# Altium schematic sheet POWER.SchDoc
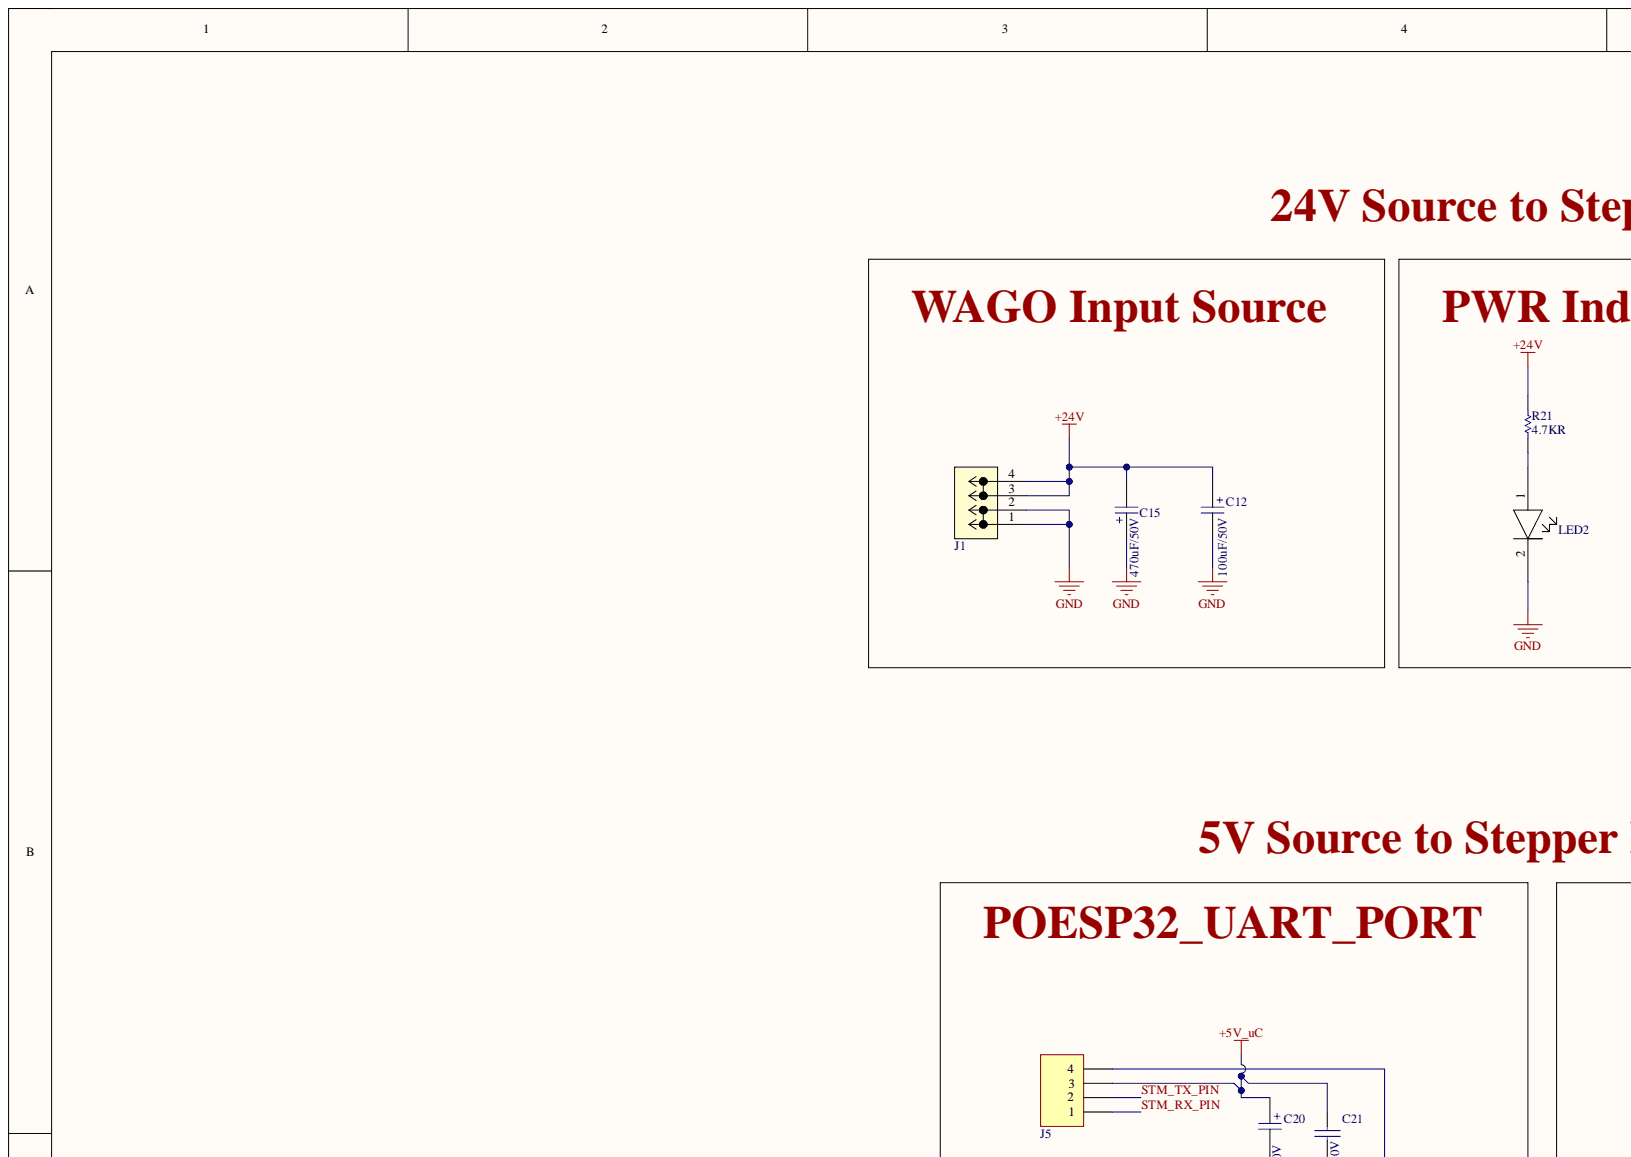
[diagram p0: POWER.SchDoc, top left]
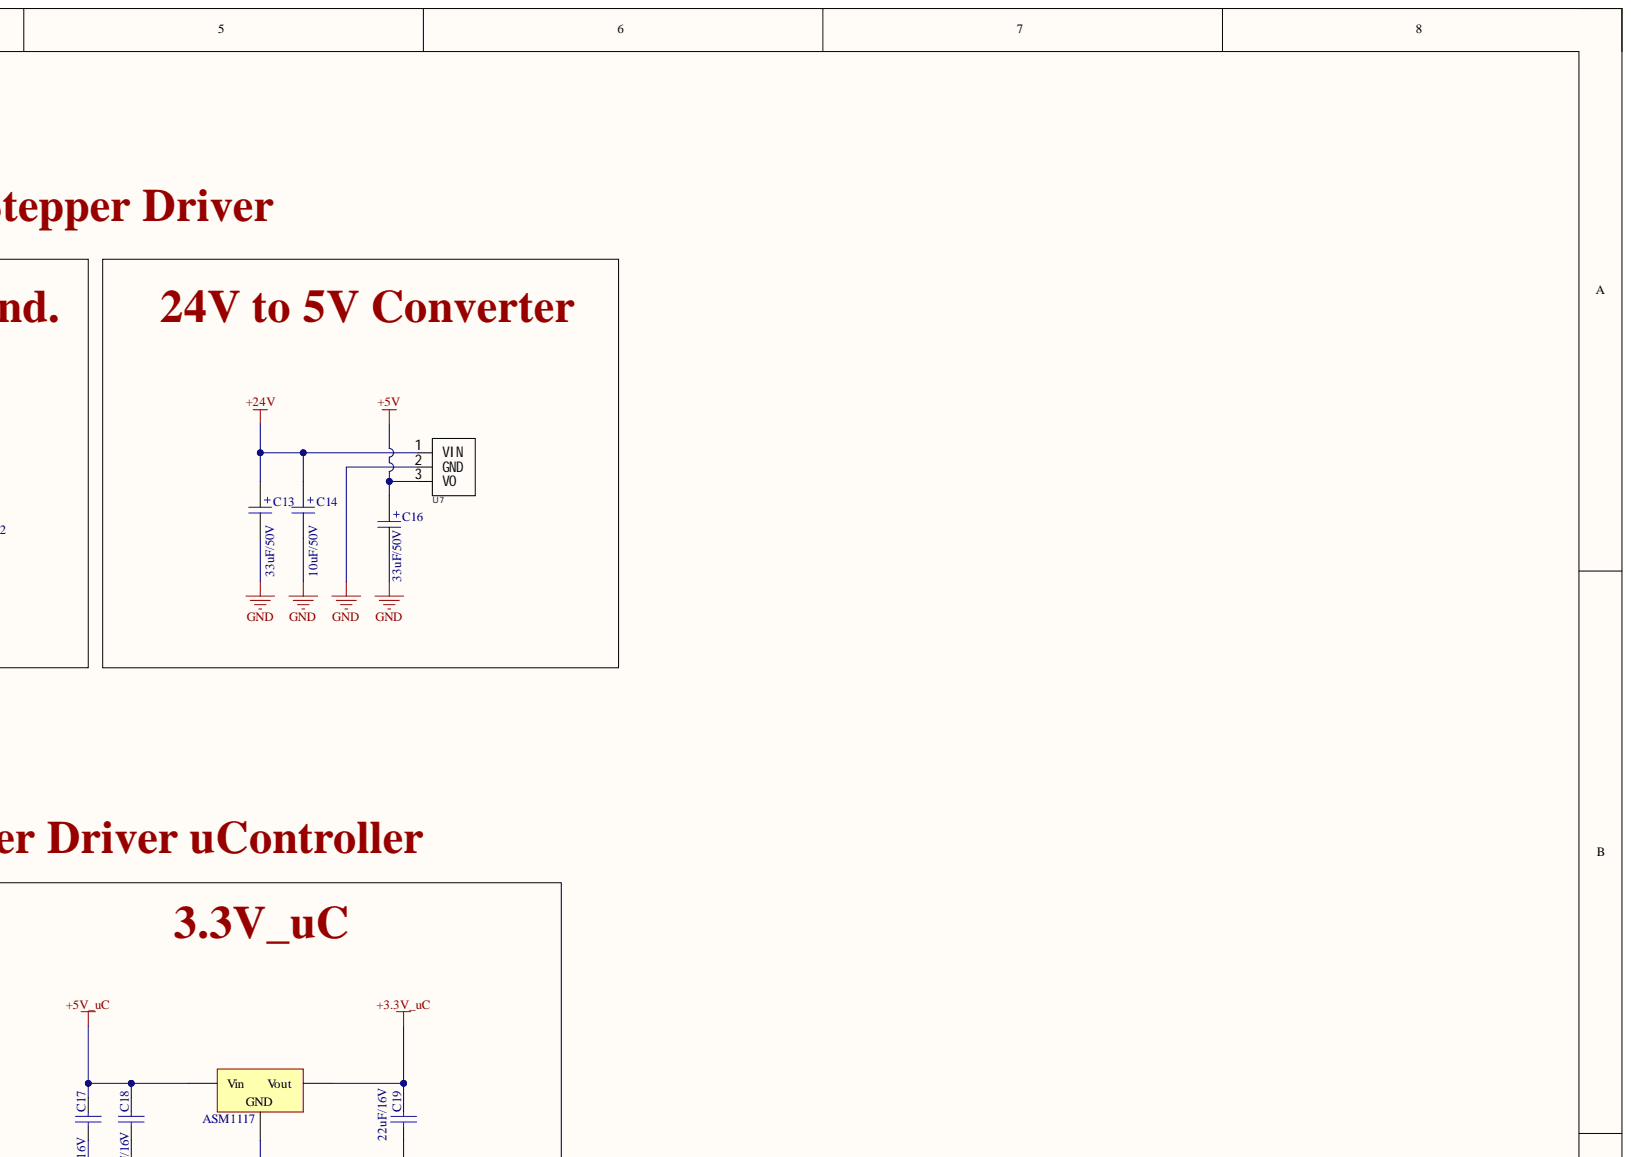
[diagram p1: POWER.SchDoc, top right]
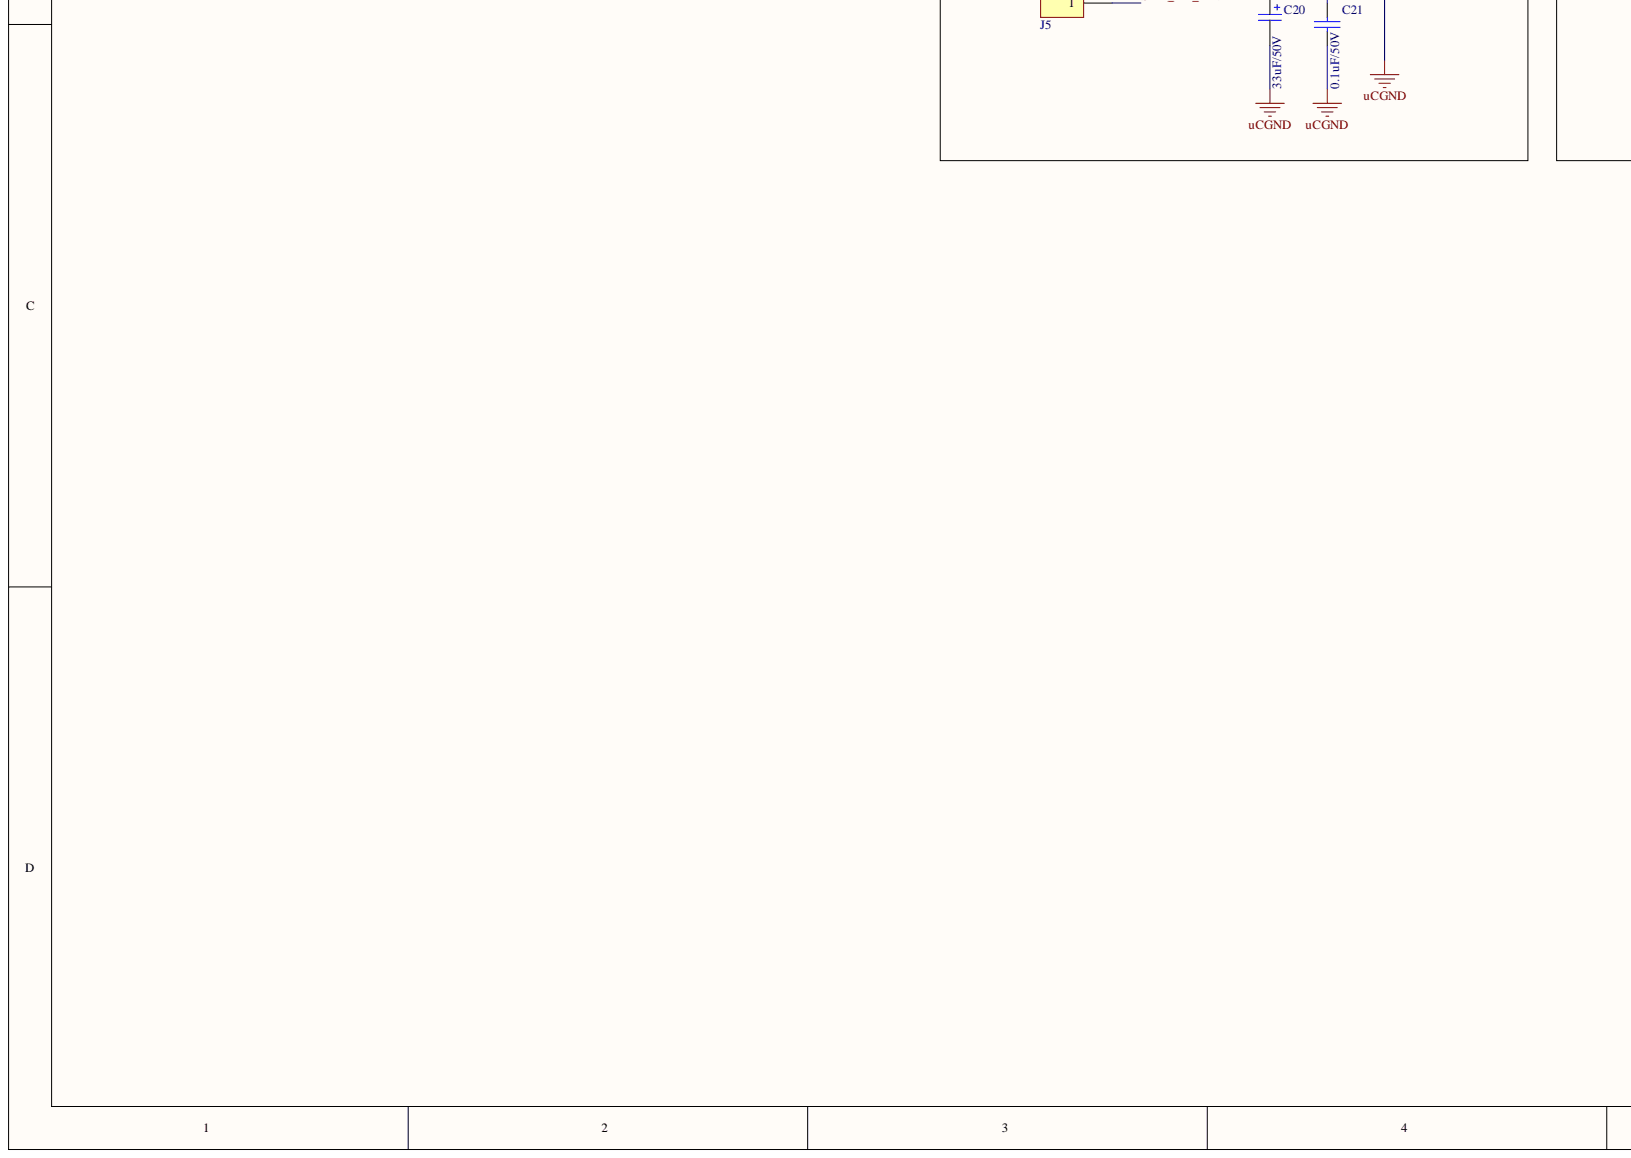
[diagram p2: POWER.SchDoc, bottom left]
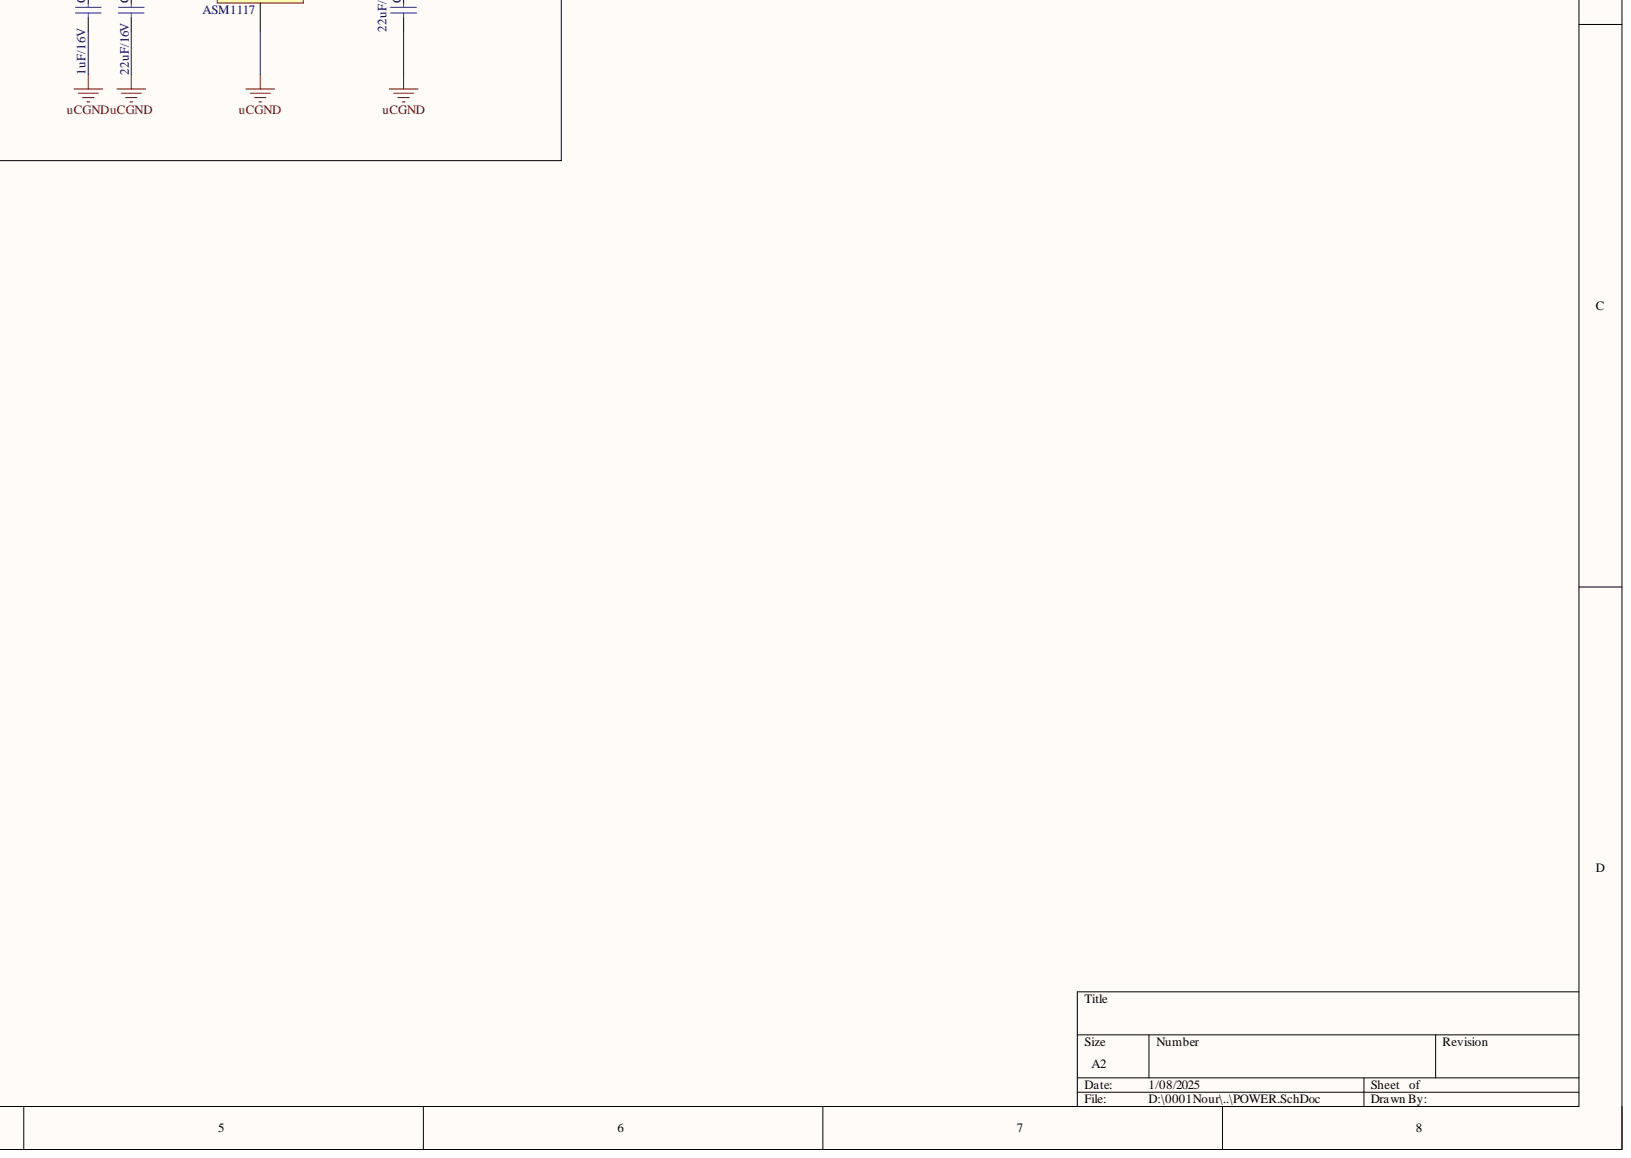
[diagram p3: POWER.SchDoc, bottom right]

## Parts
ASM1117  AMS1117 - 3.3V Reg  [SOT229P700X180-4N]  (value not drawn)
C12  100uF/50V  [CAPLEAD_D6.3mm]
C13  33uF/50V  [CAPLEAD_D6.3mm]
C14  10uF/50V  [CAPLEAD_D6.3mm]
C15  470uF/50V  [CAPLEAD_D10mm]
C16  33uF/50V  [CAPLEAD_D6.3mm]
C17  1uF/16V  [PCBComponent_1]
C18  22uF/16V  [PCBComponent_1]
C19  22uF/16V  [PCBComponent_1]
C20  33uF/50V  [CAPLEAD_D6.3mm]
C21  0.1uF/50V  [PCBComponent_1]
J1  Terminal Block  [CONN2_235-402331-000_WAGO]  (value not drawn)
J5  Header 4 Pin Polar  [PCBComponent_1]  (value not drawn)
LED2  1206 LED  [1206 LED]  (value not drawn)
R21  4.7KR  [R0402]
U7  C5369649  [SIP-3]  (value not drawn)

## Nets
+3.3V_uC: ASM1117.Vout C19.2
+5V: C16.1 U7.3
+5V_uC: ASM1117.Vin C17.2 C18.2 C20.1 C21.2 J5.3
+24V: C12.1 C13.1 C14.1 C15.2 J1.3 J1.4 R21.1 U7.1
GND: C12.2 C13.2 C14.2 C15.1 C16.2 J1.1 J1.2 LED2.2 U7.2
uCGND: ASM1117.GND C17.1 C18.1 C19.1 C20.2 C21.1 J5.4
STM_RX_PIN: J5.1
STM_TX_PIN: J5.2
NetLED2_1: LED2.1 R21.2

## Library data of the parts
ASM1117 = AMS1117 - 3.3V Reg: C347222; Component Kind: Standard; Library Name: AMS1117 - 3.3V Reg.IntLib; Created By: NourAldin
C12 = A759EQ475M2AAAE160: C47891; Manufacturer: KEMET; Category: Polymer Capacitors; Component Kind: Standard; ComponentLink1Description: http://www.kemet.com/docfinder?Partnumber=A759EQ475M2AAAE160&src-supplier=Digikey; ComponentLink1URL: http://www.kemet.com/docfinder?Partnumber=A759EQ475M2AAAE160&src-supplier=Digikey; ComponentLink2Description: http://www.farnell.com/datasheets/2095614.pdf?src-supplier=Element14; ComponentLink2URL: http://www.farnell.com/datasheets/2095614.pdf?src-supplier=Element14; Library Name: GlobalLib.IntLib; Manufacturer Part Number: A759EQ475M2AAAE160; Pricing 1: 1=0.97, 10=0.73, 50=0.73, 100=0.546, 1000=0.384, 10000=0.326 (USD); RoHS: Yes; Stock 1: 17219; Supplier 1: Mouser; Supplier Part Number 1: 80-A759EQ475M2AAE160
C13 C16 C20 = A759EQ475M2AAAE160: C45099; Manufacturer: KEMET; Category: Polymer Capacitors; Component Kind: Standard; ComponentLink1Description: http://www.kemet.com/docfinder?Partnumber=A759EQ475M2AAAE160&src-supplier=Digikey; ComponentLink1URL: http://www.kemet.com/docfinder?Partnumber=A759EQ475M2AAAE160&src-supplier=Digikey; ComponentLink2Description: http://www.farnell.com/datasheets/2095614.pdf?src-supplier=Element14; ComponentLink2URL: http://www.farnell.com/datasheets/2095614.pdf?src-supplier=Element14; Library Name: GlobalLib.IntLib; Manufacturer Part Number: A759EQ475M2AAAE160; Pricing 1: 1=0.97, 10=0.73, 50=0.73, 100=0.546, 1000=0.384, 10000=0.326 (USD); RoHS: Yes; Stock 1: 17219; Supplier 1: Mouser; Supplier Part Number 1: 80-A759EQ475M2AAE160
C14 = A759EQ475M2AAAE160: C43345; Manufacturer: KEMET; Category: Polymer Capacitors; Component Kind: Standard; ComponentLink1Description: http://www.kemet.com/docfinder?Partnumber=A759EQ475M2AAAE160&src-supplier=Digikey; ComponentLink1URL: http://www.kemet.com/docfinder?Partnumber=A759EQ475M2AAAE160&src-supplier=Digikey; ComponentLink2Description: http://www.farnell.com/datasheets/2095614.pdf?src-supplier=Element14; ComponentLink2URL: http://www.farnell.com/datasheets/2095614.pdf?src-supplier=Element14; Library Name: GlobalLib.IntLib; Manufacturer Part Number: A759EQ475M2AAAE160; Pricing 1: 1=0.97, 10=0.73, 50=0.73, 100=0.546, 1000=0.384, 10000=0.326 (USD); RoHS: Yes; Stock 1: 17219; Supplier 1: Mouser; Supplier Part Number 1: 80-A759EQ475M2AAE160
C15 = MAL215058101E3: C2960279; Manufacturer: Vishay BCcomponents; Category: Aluminum Electrolytic Capacitors; Component Kind: Standard; ComponentLink1Description: https://datasheet.ciiva.com/11697/150rmi-11697723.pdf?src-supplier=Avnet; ComponentLink1URL: https://datasheet.ciiva.com/11697/150rmi-11697723.pdf?src-supplier=Avnet; Library Name: GlobalLib.IntLib; Manufacturer Part Number: MAL215058101E3; Pricing 1: 1=1.45, 10=1.09, 50=1.09, 100=0.799, 1000=0.585, 10000=0.496 (USD); RoHS: Yes; Stock 1: 1562; Supplier 1: Mouser; Supplier 2: LCSC; Supplier Part Number 1: 594-2222-150-58101; Supplier Part Number 2: C1596751
C17 = 0603 Capacitor: C1592; Component Kind: Standard; DataSheet Link: https://eu.mouser.com/datasheet/2/400/lcc_automotive_general_en-843974.pdf; Library Name: 0603 Capacitor.IntLib; Mouser Link: https://eu.mouser.com/ProductDetail/TDK/CGA1A2X7R1H331M030BA?qs=sGAEpiMZZMs0AnBnWHyRQL8L3bkL%252bvtyS9XpSB1mk7rVV5K8%252bbxEeg%3d%3d; Created By: NourAldin
C18 C19 = 0603 Capacitor: C2762594; Component Kind: Standard; DataSheet Link: https://eu.mouser.com/datasheet/2/400/lcc_automotive_general_en-843974.pdf; Library Name: 0603 Capacitor.IntLib; Mouser Link: https://eu.mouser.com/ProductDetail/TDK/CGA1A2X7R1H331M030BA?qs=sGAEpiMZZMs0AnBnWHyRQL8L3bkL%252bvtyS9XpSB1mk7rVV5K8%252bbxEeg%3d%3d; Created By: NourAldin
C21 = 0603 Capacitor: C1591; Component Kind: Standard; DataSheet Link: https://eu.mouser.com/datasheet/2/400/lcc_automotive_general_en-843974.pdf; Library Name: 0603 Capacitor.IntLib; Mouser Link: https://eu.mouser.com/ProductDetail/TDK/CGA1A2X7R1H331M030BA?qs=sGAEpiMZZMs0AnBnWHyRQL8L3bkL%252bvtyS9XpSB1mk7rVV5K8%252bbxEeg%3d%3d; Created By: NourAldin
J1 = 235-402331-000: C7461083; Mfr_Name: Wago; Component Kind: Standard; Library Name: LIB.SchLib; RefDes: RefDes; Type: Type
R21 = 0402 Resistor: C105871; Component Kind: Standard; Library Name: DIVERSE.IntLib
U7 = K7805M-1000R3_C5369649: Manufacturer: YLPTEC(易川); Add into BOM: yes; Conversion Efficiency: 94%; Conversion Type: DC-DC; Function: Boost type; Input Voltage(DC): 7V~36V; Maximum Capacitive Load: 2200uF; Output Channel: 1; Output Current (Max): 1000mA; Output Power: 5W; Output Voltage: 5V; Component Kind: Standard; Convert to PCB: yes; Datasheet: https://atta.szlcsc.com/upload/public/pdf/source/20230612/70568B8922D00E56BE7D7C892197C716.pdf; Device: K7805M-1000R3_C5369649; Feature: Short-Circuit  Protection；Low-Power Consumption; JLCPCB Part Class: Extended Part; LCSC Part Name: K7805M-1000R3; Library Name: LIB.SchLib; Manufacturer Part: K7805M-1000R3; Supplier: LCSC; Supplier Footprint: SIP-3; Supplier Part: C5369649; Symbol: K7805M-1000R3_C5369649
J5 = Header 4 Pin Polar: Component Kind: Standard; Description: Header 4 Pin Polar; Library Name: Header 4 Pin Polar.IntLib
LED2 = 1206 LED: Component Kind: Standard; Description: 1206 LED; Library Name: 1206 LED.IntLib; Created By: NourAldin
